# Altium schematic sheet XYPowerSupplyMB-Rev2b.SchDoc(XYPowerSupplyMB-Rev2b)
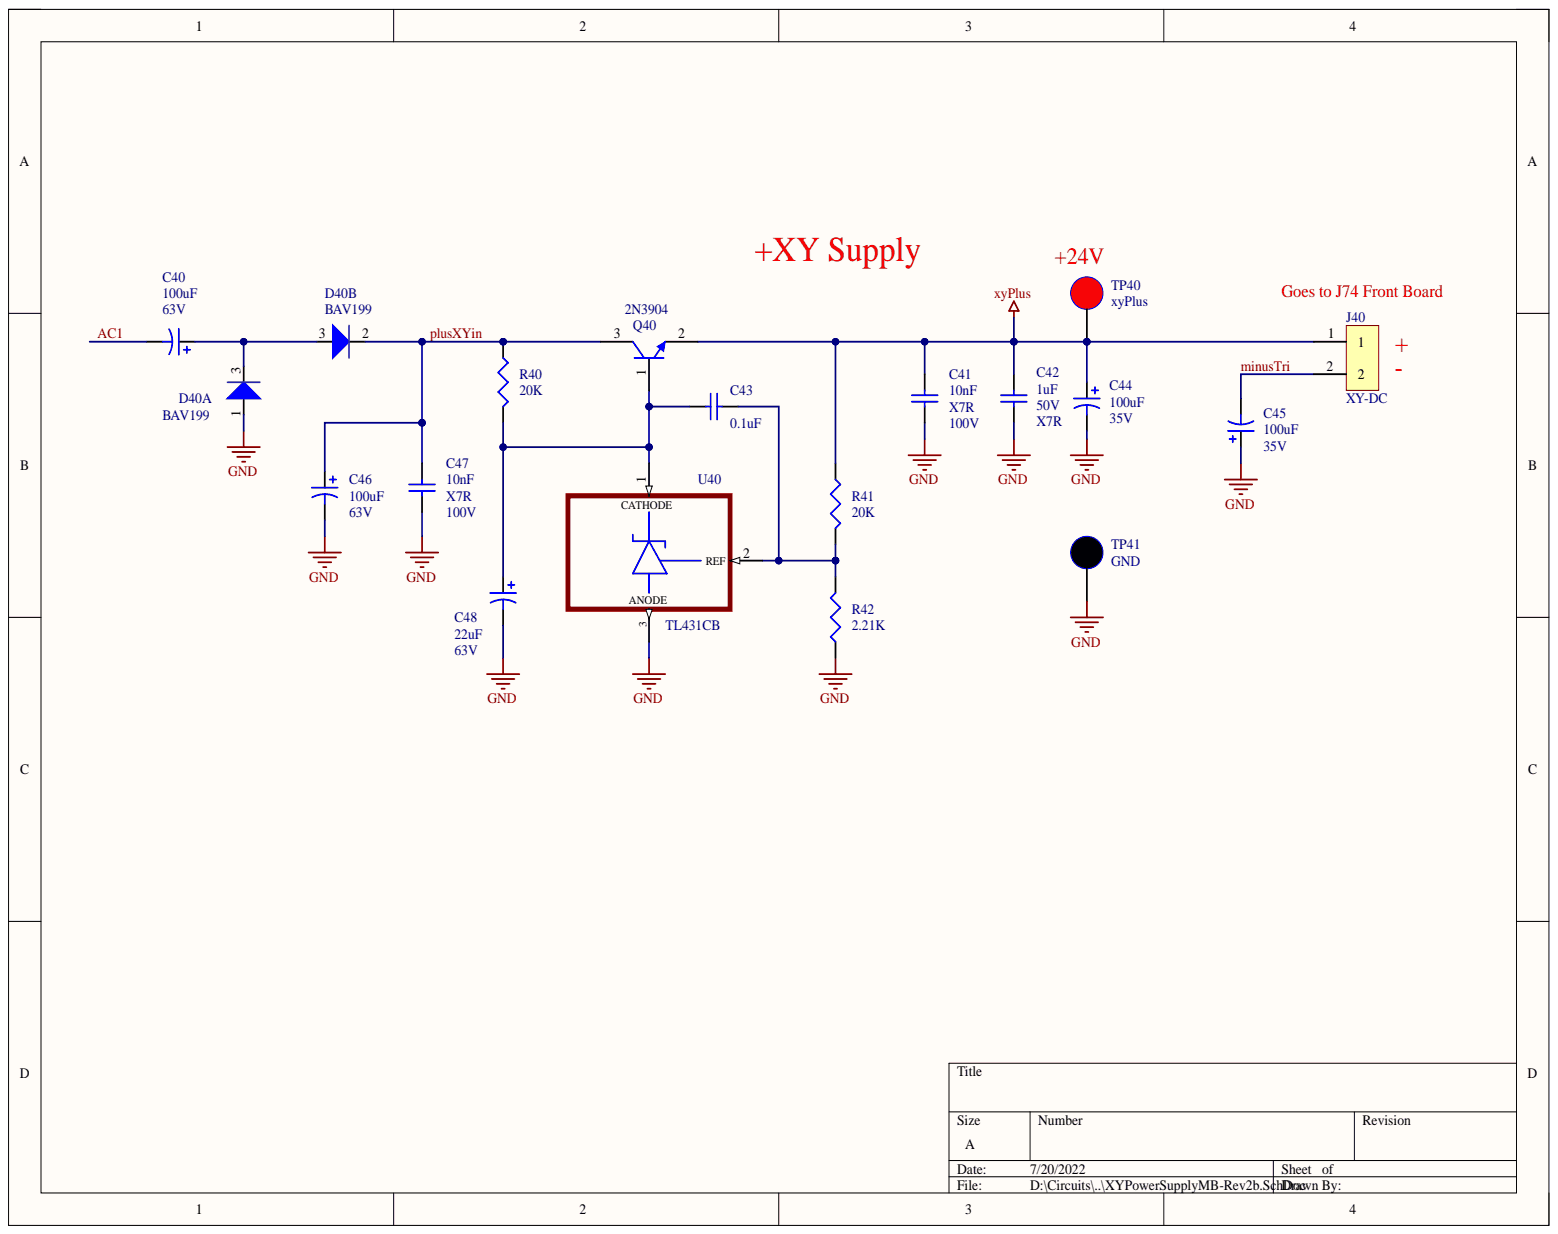
[diagram p0: XYPowerSupplyMB-Rev2b.SchDoc]

## Parts
C40  100uF  Voltage Rating: 63V  [CAPPR3.5-8X12-0.7d]
C41  10nF  Dielectric: X7R  Voltage: 100V  [CAPC2012M]
C42  1uF  Dielectric: X7R  Voltage: 50V  [CAPC2012M]
C43  value 0.1uF  [CAPC2012M]  (hidden comment: 10nF)
C44  100uF  Voltage: 35V  [CAPPR2.5-6.3X10]
C45  100uF  Voltage: 35V  [CAPPR2.5-6.3X10]
C46  100uF  Voltage Rating: 63V  [CAPPR3.5-8X12-0.7d]
C47  10nF  Dielectric: X7R  Voltage: 100V  [CAPC2012M]
C48  value 22uF  Capacitance: 22uF  Voltage: 63V  [CAPPR2-5x11]  (hidden comment: 100uF)
D40  BAV199  [SOT23-3M]  (parts A,B)
J40  XY-DC  [DTA-100-2]
Q40  2N3904  [SOT23-3M]
R40  20K  [RESC2012M]
R41  20K  [RESC2012M]
R42  2.21K  [RESC2012M]
TP40  xyPlus  [TP-.038]
TP41  GND  [TP-.038]
U40  TL431CB  [SOT23-3M]

## Nets
GND: C41.2 C42.2 C44.2 C45.1 C46.2 C47.2 C48.2 D40.1 R42.2 TP41.1 U40.3
xyPlus: C41.1 C42.1 C44.1 J40.1 Q40.2 R41.1 TP40.1
AC1: C40.2
minusTri: C45.2 J40.2
plusXYin: C46.1 C47.1 D40.2 Q40.3 R40.1
NetC40_1: C40.1 D40.3
NetC43_1: C43.1 R41.2 R42.1 U40.2
NetC43_2: C43.2 C48.1 Q40.1 R40.2 U40.1

## Library data of the parts
C40 C46 = Cap Pol1: CAP ALUM 100UF 63V 20%; Manufacturer: KEMET; Manufacturer Part Number: ESL107M063AG8AA; ESR (Equivalent Series Resistance): 170mR; Life (Hours): 10000Hour; Polarity: Polar; Capacitance: 100uF; Case/Package: Radial; Category: Aluminum Electrolytic Capacitors; Component Kind: Standard; ComponentLink1Description: http://www.farnell.com/datasheets/1879581.pdf?src-supplier=Farnell; ComponentLink1URL: http://www.farnell.com/datasheets/1879581.pdf?src-supplier=Farnell; ComponentLink2Description: http://www.produktinfo.conrad.com/datenblaetter/1200000-1299999/001280187-da-01-en-WCAP_ATG8_ALU_ELEC_CAPS_860010674014.pdf?src-supplier=Conrad; ComponentLink2URL: http://www.produktinfo.conrad.com/datenblaetter/1200000-1299999/001280187-da-01-en-WCAP_ATG8_ALU_ELEC_CAPS_860010674014.pdf?src-supplier=Conrad; ComponentLink3Description: http://katalog.we-online.de/pbs/datasheet/860010674014.pdf?src-supplier=Digi-Key; ComponentLink3URL: http://katalog.we-online.de/pbs/datasheet/860010674014.pdf?src-supplier=Digi-Key; ComponentLink4Description: http://www.farnell.com/datasheets/1879581.pdf?src-supplier=Newark; ComponentLink4URL: http://www.farnell.com/datasheets/1879581.pdf?src-supplier=Newark; ComponentLink5Description: http://www.farnell.com/datasheets/1879581.pdf?src-supplier=Element14; ComponentLink5URL: http://www.farnell.com/datasheets/1879581.pdf?src-supplier=Element14; ComponentLink6Description: https://api.kemet.com/component-edge/download/datasheet/ESL107M063AG8AA.pdf?src-supplier=Digi-Key; ComponentLink6URL: https://api.kemet.com/component-edge/download/datasheet/ESL107M063AG8AA.pdf?src-supplier=Digi-Key; Library Name: Miscellaneous Devices.IntLib; Max Operating Temperature: 105°C; Min Operating Temperature: -40°C; Mount: Through Hole; Packaging: Bulk; Pricing 1: 1=0.52000, 10=0.36500, 50=0.27600, 100=0.24030, 500=0.17802, 1000=0.15132, 2500=0.14242, 5000=0.13352 (USD); RoHS: Yes; RoHS Compliant: Yes; Signal Integrity: Cap Pol; Simulation: CAP; Stock 1: 4994; Supplier: Digi-Key; Supplier Part Number: 399-15743-ND; Tolerance: 20%; Value: 100uF
C41 C47 = Cap: CAP CER 10NF 100V X7R 0805; Manufacturer: Samsung; Manufacturer Part Number: CL21B103KCANNNC; Dielectric Material: Ceramic; Dissipation Factor: 2.5%; Tolerance: 10%; Capacitance: 10nF; Case Code (Imperial): 0805; Case Code (Metric): 2012; Case/Package: 0805; Component Kind: Standard; Construction: Flat; Contact Plating: Tin; Depth: 1.25mm; Height: 0.65mm; Length: 2mm; Library Name: Miscellaneous Devices.IntLib; Material: Ceramic; Max Operating Temperature: 125°C; Min Operating Temperature: -55°C; Mount: Surface Mount; Number of Pins: 2; Package Quantity: 1; Packaging: Tape and Reel; Radiation Hardening: No; REACH SVHC: No SVHC; RoHS Compliant: Yes; Series: CL; Signal Integrity: Cap; Simulation: CAP; Supplier: Digi-Key; Supplier Part Number: 1276-1249-6-ND; Termination: SMD/SMT; Thickness: 0.03inch; Value: 10nF; Voltage Rating: 100V; Voltage Rating (DC): 100V; Width: 0.049inch
C42 = Cap: CAP CER 1UF 50V X7R 0805; Manufacturer: Samsung; Manufacturer Part Number: CL21B105KBFNNNE; Dielectric Material: Ceramic; Tolerance: 10%; Capacitance: 1uF; Case Code (Imperial): 0805; Case Code (Metric): 2012; Case/Package: 0805; Component Kind: Standard; Construction: Flat; Depth: 1.25mm; Height: 1.25mm; Length: 2mm; Library Name: Miscellaneous Devices.IntLib; Max Operating Temperature: 125°C; Min Operating Temperature: -55°C; Mount: Surface Mount; Number of Pins: 2; Package Quantity: 1; Packaging: Tape and Reel; Radiation Hardening: No; REACH SVHC: No SVHC; RoHS Compliant: Yes; Series: CL; Signal Integrity: Cap; Simulation: CAP; Supplier: Digi-Key; Supplier Part Number: 1276-1029-6-ND; Termination: SMD/SMT; Thickness: 0.053inch; Value: 1uF; Voltage Rating: 50V; Voltage Rating (DC): 50V; Width: 0.049inch
C43 = Cap: CAP CER 0.1UF 50V X7R 0805; Manufacturer: KEMET; Manufacturer Part Number: C0805C104M5RACTU; Capacitance: 100nF; Dielectric Material: Ceramic; Dissipation Factor: 2.5%; Tolerance: 20%; Case Code (Imperial): 0805; Case Code (Metric): 2012; Case/Package: 0805; Category: Ceramic Capacitors; Component Kind: Standard; ComponentLink1Description: http://www.farnell.com/datasheets/2739857.pdf?src-supplier=Farnell; ComponentLink1URL: http://www.farnell.com/datasheets/2739857.pdf?src-supplier=Farnell; ComponentLink2Description: http://components.arrow.com/part/dataview/0323_00324812?src-supplier=Arrow+Europe; ComponentLink2URL: http://components.arrow.com/part/dataview/0323_00324812?src-supplier=Arrow+Europe; ComponentLink3Description: https://www.verical.com/server-webapp/html/getDatasheet?partID=774625&src-supplier=Verical; ComponentLink3URL: https://www.verical.com/server-webapp/html/getDatasheet?partID=774625&src-supplier=Verical; ComponentLink4Description: http://uk.rs-online.com/webdocs/1515/0900766b81515223.pdf?src-supplier=RSComponents; ComponentLink4URL: http://uk.rs-online.com/webdocs/1515/0900766b81515223.pdf?src-supplier=RSComponents; ComponentLink5Description: http://uk.rs-online.com/webdocs/1528/0900766b81528e2f.pdf?src-supplier=RSComponents; ComponentLink5URL: http://uk.rs-online.com/webdocs/1528/0900766b81528e2f.pdf?src-supplier=RSComponents; ComponentLink6Description: http://uk.rs-online.com/webdocs/13da/0900766b813daa06.pdf?src-supplier=RSComponents; ComponentLink6URL: http://uk.rs-online.com/webdocs/13da/0900766b813daa06.pdf?src-supplier=RSComponents; ComponentLink7Description: http://components.arrow.com/part/dataview/2091108S8109171N7429?src-supplier=Arrow+Electronics; ComponentLink7URL: http://components.arrow.com/part/dataview/2091108S8109171N7429?src-supplier=Arrow+Electronics; ComponentLink8Description: http://www.alliedelec.com/m/d/9bfe7773041ffe9d406e68bc7b377fdd.pdf?src-supplier=Allied+Electronics; ComponentLink8URL: http://www.alliedelec.com/m/d/9bfe7773041ffe9d406e68bc7b377fdd.pdf?src-supplier=Allied+Electronics; ComponentLink9Description: https://api.kemet.com/component-edge/download/datasheet/C0805C104M5RACTU.pdf?src-supplier=Digi-Key; ComponentLink9URL: https://api.kemet.com/component-edge/download/datasheet/C0805C104M5RACTU.pdf?src-supplier=Digi-Key; ComponentLink10Description: http://www.farnell.com/datasheets/2739857.pdf?src-supplier=Element14; ComponentLink10URL: http://www.farnell.com/datasheets/2739857.pdf?src-supplier=Element14; ComponentLink11Description: http://www.farnell.com/datasheets/2739857.pdf?src-supplier=Newark; ComponentLink11URL: http://www.farnell.com/datasheets/2739857.pdf?src-supplier=Newark; ComponentLink12Description: http://capacitoredge.kemet.com/capedge2/DataSheet?pn=C0805C104M5RACTU&src-supplier=Avnet+Europe; ComponentLink12URL: http://capacitoredge.kemet.com/capedge2/DataSheet?pn=C0805C104M5RACTU&src-supplier=Avnet+Europe; ComponentLink13Description: https://www.verical.com/server-webapp/html/getDatasheet?partID=91523&src-supplier=Verical; ComponentLink13URL: https://www.verical.com/server-webapp/html/getDatasheet?partID=91523&src-supplier=Verical; ComponentLink14Description: http://www.mouser.com/ds/2/212/KEM_C1002_X7R_SMD-1102033.pdf?src-supplier=Mouser; ComponentLink14URL: http://www.mouser.com/ds/2/212/KEM_C1002_X7R_SMD-1102033.pdf?src-supplier=Mouser; Construction: Flat; Depth: 1.25mm; Dielectric: X7R; Height: 0.9mm; Length: 2mm; Library Name: Miscellaneous Devices.IntLib; Max Operating Temperature: 125°C; Min Operating Temperature: -55°C; Mount: Surface Mount,PCB; Number of Pins: 2; Package Quantity: 1; Packaging: Tape and Reel; Pricing 1: 4000=0.01341, 8000=0.01224 (USD); Radiation Hardening: No; REACH SVHC: No SVHC; RoHS: Yes; Series: C; Signal Integrity: Cap; Simulation: CAP; Stock 1: 1771581; Supplier: Digi-Key; Supplier Part Number: 399-C0805C104M5RAC7800TR-ND; Termination: SMD/SMT; Thickness: 0.035inch; Voltage: 50V; Voltage Rating: 50V; Voltage Rating (DC): 50V; Weight: 0.000194oz; Width: 1.2mm
C44 C45 = Cap Pol1: CAP ALUM 100UF 20% 35V THRU HOLE; Manufacturer: Rubycon; Manufacturer Part Number: 35YXJ100M6.3X11; Impedance: 220mR; Lead Diameter: 0.5mm; Leakage Current: 3uA; Life (Hours): 7000Hour; Polarity: Polar; Ripple Current: 400mA; Capacitance: 100uF; Case/Package: Radial; Component Kind: Standard; Diameter: 6.3mm; Height: 11mm; Height - Seated (Max): 0.492inch; Lead Pitch: 2.5mm; Library Name: Miscellaneous Devices.IntLib; Max Operating Temperature: 105°C; Min Operating Temperature: -40°C; Mount: Through Hole; Package Quantity: 3000; Packaging: Bulk; Radiation Hardening: No; Signal Integrity: Cap Pol; Simulation: CAP; Supplier: Digi-Key; Supplier Part Number: 1189-2260-ND; Termination: Radial; Tolerance: 20%; Value: 100uF; Voltage Rating: 35V
C48 = Cap Pol1: CAP ALUM 22UF 63V 20%; Manufacturer: Rubycon; Manufacturer Part Number: 63PK22MEFC5X11; Lead Diameter: 0.5mm; Leakage Current: 3uA; Life (Hours): 2000Hour; Ripple Current: 110mA; Case/Package: Radial; Category: Aluminum Electrolytic Capacitors; Component Kind: Standard; ComponentLink1Description: http://www.farnell.com/datasheets/1879581.pdf?src-supplier=Farnell; ComponentLink1URL: http://www.farnell.com/datasheets/1879581.pdf?src-supplier=Farnell; ComponentLink2Description: http://www.produktinfo.conrad.com/datenblaetter/1200000-1299999/001280187-da-01-en-WCAP_ATG8_ALU_ELEC_CAPS_860010674014.pdf?src-supplier=Conrad; ComponentLink2URL: http://www.produktinfo.conrad.com/datenblaetter/1200000-1299999/001280187-da-01-en-WCAP_ATG8_ALU_ELEC_CAPS_860010674014.pdf?src-supplier=Conrad; ComponentLink3Description: http://katalog.we-online.de/pbs/datasheet/860010674014.pdf?src-supplier=Digi-Key; ComponentLink3URL: http://katalog.we-online.de/pbs/datasheet/860010674014.pdf?src-supplier=Digi-Key; ComponentLink4Description: http://www.farnell.com/datasheets/1879581.pdf?src-supplier=Newark; ComponentLink4URL: http://www.farnell.com/datasheets/1879581.pdf?src-supplier=Newark; ComponentLink5Description: http://www.farnell.com/datasheets/1879581.pdf?src-supplier=Element14; ComponentLink5URL: http://www.farnell.com/datasheets/1879581.pdf?src-supplier=Element14; ComponentLink6Description: https://datasheet.ciiva.com/46385/1657883-46385279.pdf?src-supplier=Element14; ComponentLink6URL: https://datasheet.ciiva.com/46385/1657883-46385279.pdf?src-supplier=Element14; ComponentLink7Description: https://datasheet.ciiva.com/25613/0900766b810f8819-25613314.pdf?src-supplier=RSComponents; ComponentLink7URL: https://datasheet.ciiva.com/25613/0900766b810f8819-25613314.pdf?src-supplier=RSComponents; Construction: RADIAL; Diameter: 5mm; Height: 11mm; Lead Pitch: 2mm; Library Name: Miscellaneous Devices.IntLib; Max Operating Temperature: 85°C; Min Operating Temperature: -40°C; Mount: Through Hole; Pricing 1: 1=0.30000, 10=0.20700, 50=0.13800, 100=0.11730, 500=0.08280, 1000=0.07245, 2500=0.06900, 5000=0.06382 (USD); Radiation Hardening: No; RoHS: Yes; RoHS Compliant: Yes; Signal Integrity: Cap Pol; Simulation: CAP; Stock 1: 404; Supplier: Digi-Key; Supplier Part Number: 63PK22MEFC5X11-ND; Termination: Radial; Tolerance: 20%
D40 = Dual-Si-Series-Separate: Rectifier Diode Switching 85V 0.16A 3000ns Automotive 3-Pin SOT-23 T/R; Manufacturer: Nexperia; Manufacturer Part Number: BAV199,215; Category: Rectifier Diodes; Component Kind: Standard; ComponentLink1Description: http://www.farnell.com/datasheets/2242062.pdf?src-supplier=Farnell; ComponentLink1URL: http://www.farnell.com/datasheets/2242062.pdf?src-supplier=Farnell; Library Name: MY_Diodes.SchLib; Pricing 1: 1=0.23000, 10=0.18900, 100=0.10030, 500=0.06600, 1000=0.04488 (USD); RoHS: Yes; RoHS Compliant: Yes; Stock 1: 68397; Supplier: Digi-Key; Supplier Part Number: 1727-2909-1-ND; Value: BAV199
J40 = 2-Pin: 2.54mm 2pin; Manufacturer: TE Connectivity; Manufacturer Part Number: 640456-2; Approvals: CSA; Backwall/Post Interruptions: No (Without); Circuit Application: Signal; Connector System: Wire-to-Board; Connector Type: Connector,Pin,Plug,Header; Contact Current Rating: 5A; Contact Post Length: 3.56mm; Contact Resistance: 6mR; Contact Style: Straight; Gender: Male; Mating Connector Lock: Yes (With); Max Voltage Rating (AC): 250V; Number of Contacts: 2; PCB Mount Retention: Without; PCB Mounting Orientation: Vertical; PCB Thickness: 0.063inch; Plating Thickness: 3.81um; Tail Length: 0.14inch; Value: 640456-2; Category: Headers and Wire Housings; Color: Natural,White,Beige; Component Kind: Standard; ComponentLink1Description: https://datasheet.ciiva.com/709/eng-cd-640456-w1-709680.pdf?src-supplier=Digi-Key; ComponentLink1URL: https://datasheet.ciiva.com/709/eng-cd-640456-w1-709680.pdf?src-supplier=Digi-Key; ComponentLink2Description: https://datasheet.ciiva.com/3118/eng-ds-82056-mta-0608-3118497.pdf?src-supplier=Avnet; ComponentLink2URL: https://datasheet.ciiva.com/3118/eng-ds-82056-mta-0608-3118497.pdf?src-supplier=Avnet; ComponentLink3Description: https://datasheet.ciiva.com/16401/eng-ds-82056-mta-0608-16401289.pdf?src-supplier=Future+Electronics; ComponentLink3URL: https://datasheet.ciiva.com/16401/eng-ds-82056-mta-0608-16401289.pdf?src-supplier=Future+Electronics; ComponentLink4Description: https://datasheet.ciiva.com/25617/0900766b80027008-25617244.pdf?src-supplier=RSComponents; ComponentLink4URL: https://datasheet.ciiva.com/25617/0900766b80027008-25617244.pdf?src-supplier=RSComponents; ComponentLink5Description: https://datasheet.ciiva.com/14156/04384301-14156820.pdf?src-supplier=Distrelec; ComponentLink5URL: https://datasheet.ciiva.com/14156/04384301-14156820.pdf?src-supplier=Distrelec; ComponentLink6Description: https://datasheet.ciiva.com/11746/0900766b80eb7fdd-11746365.pdf?src-supplier=RSComponents; ComponentLink6URL: https://datasheet.ciiva.com/11746/0900766b80eb7fdd-11746365.pdf?src-supplier=RSComponents; ComponentLink7Description: https://datasheet.ciiva.com/7128/1866390-7128742.pdf?src-supplier=Newark; ComponentLink7URL: https://datasheet.ciiva.com/7128/1866390-7128742.pdf?src-supplier=Newark; ComponentLink8Description: https://datasheet.ciiva.com/16505/eng-ds-82056-mta-0608-16505419.pdf?src-supplier=Avnet+Asia; ComponentLink8URL: https://datasheet.ciiva.com/16505/eng-ds-82056-mta-0608-16505419.pdf?src-supplier=Avnet+Asia; ComponentLink9Description: https://datasheet.ciiva.com/18620/1713017-18620870.pdf?src-supplier=Digi-Key; ComponentLink9URL: https://datasheet.ciiva.com/18620/1713017-18620870.pdf?src-supplier=Digi-Key; ComponentLink10Description: https://datasheet.ciiva.com/16047/1989748-16047438.pdf?src-supplier=Onlinecomponents.com; ComponentLink10URL: https://datasheet.ciiva.com/16047/1989748-16047438.pdf?src-supplier=Onlinecomponents.com; ComponentLink11Description: https://datasheet.ciiva.com/11758/0900766b811d404e-11758587.pdf?src-supplier=RSComponents; ComponentLink11URL: https://datasheet.ciiva.com/11758/0900766b811d404e-11758587.pdf?src-supplier=RSComponents; ComponentLink12Description: https://datasheet.ciiva.com/6746/combiconspring-cagepcbterm-blocks-6746175.pdf?src-supplier=Digi-Key; ComponentLink12URL: https://datasheet.ciiva.com/6746/combiconspring-cagepcbterm-blocks-6746175.pdf?src-supplier=Digi-Key; ComponentLink13Description: https://datasheet.ciiva.com/14607/70055059-14607702.pdf?src-supplier=Allied+Electronics; ComponentLink13URL: https://datasheet.ciiva.com/14607/70055059-14607702.pdf?src-supplier=Allied+Electronics; ComponentLink14Description: https://datasheet.ciiva.com/16051/1988956-16051359.pdf?src-supplier=Onlinecomponents.com; ComponentLink14URL: https://datasheet.ciiva.com/16051/1988956-16051359.pdf?src-supplier=Onlinecomponents.com; ComponentLink15Description: https://datasheet.ciiva.com/26340/567541-da-01-de-printklemme-1988956-250-st-26340451.pdf?src-supplier=Conrad; ComponentLink15URL: https://datasheet.ciiva.com/26340/567541-da-01-de-printklemme-1988956-250-st-26340451.pdf?src-supplier=Conrad; ComponentLink16Description: https://datasheet.ciiva.com/824/combiconcompactseries-824224.pdf?src-supplier=Digi-Key; ComponentLink16URL: https://datasheet.ciiva.com/824/combiconcompactseries-824224.pdf?src-supplier=Digi-Key; ComponentLink17Description: https://datasheet.ciiva.com/14609/70055171-14609100.pdf?src-supplier=Allied+Electronics; ComponentLink17URL: https://datasheet.ciiva.com/14609/70055171-14609100.pdf?src-supplier=Allied+Electronics; Contact Gender: Male; Contact Material: Copper Alloy; Contact Mating Length: 7.49mm; Contact Plating: Tin; Contact Retention: No (Without); Contact Shape: Square; Contact Type: Male Pin; Current Rating: 5A; Depth: 5.72mm; ELV: Compliant; Fastening Type: Friction; Flammability Rating: UL94 V-0; Height: 7.87mm; Housing Color: Natural; Housing Material: Polyester; Lead Free: Lead Free; Lead Pitch: 2.54mm; Length: 7.62mm; Library Name: MY_CONNECTORS.SchLib; Mating Alignment: No (Without); Mating Retention: No (Without); Max Operating Temperature: 221°C; Min Operating Temperature: -67°C; Mount: Through Hole; Mounting Ears: No (Without); Number of Poles: 2; Number of Positions: 2; Number of Rows: 1; Orientation: Straight; Package Quantity: 5000; Packaging: Bulk; Panel Mount Retention: No (Without); PCB Mount Alignment: No (Without); Pitch: 2.54mm; Pricing 1: 1=0.13000, 10=0.10400, 100=0.07700, 500=0.06374, 1000=0.05578, 5000=0.04781, 10000=0.04648 (USD); Radiation Hardening: No; REACH SVHC: No SVHC; RoHS: Yes; RoHS Compliant: Yes; Sealable: No (Without); Series: MTA-100; Shrouded: No (Without); Stock 1: 18986; Strain Relief: No (Without); Supplier: Digi-Key; Supplier Part Number: A1921-ND; Termination: Solder; Voltage Rating: 250V; Voltage Rating (AC): 250V; Working Voltage: 250V
Q40 = 2N3904: TRANS NPN 40V 0.2A SOT23; Manufacturer: ON Semiconductor; Manufacturer Part Number: MMBT3904LT1G; Current - Collector (Ic) (Max): 200mA; DC Current Gain (hFE) (Min) @ Ic, Vce: 100 @ 10mA, 1V; Frequency - Transition: 300MHz; Power - Max: 300mW; Vce Saturation (Max) @ Ib, Ic: 300mV @ 5mA, 50mA; Category: Discrete Semiconductor Products; Code_JEDEC: TO-92A; Component Kind: Standard; ComponentLink1Description: Manufacturer Link; ComponentLink1URL: http://www.fairchildsemi.com/; ComponentLink2Description: Datasheet; ComponentLink2URL: http://www.fairchildsemi.com/ds/2N%2F2N3904.pdf; LatestRevisionDate: 15-Jan-2003; LatestRevisionNote: PCB Footprint 'BCY-W3/D4.7' replaced; Library Name: My_Miscellaneous Devices.SchLib; Mounting Type: Surface Mount; Operating Temperature: -55°C ~ 150°C (TJ); Package / Case: TO-236-3, SC-59, SOT-23-3; PackageVersion: Sep-1998; Packaging: Cut Tape (CT); Part Status: Active; RoHS: RoHS Compliant; Signal Integrity: 2N3904; Simulation: 2N3904; Supplier: Digi-Key; Supplier Device Package: SOT-23-3 (TO-236); Supplier Part Number: MMBT3904LT1GOSCT-ND; Transistor Type: NPN; Value: 2N3904; Voltage - Collector Emitter Breakdown (Max): 40V
R40 R41 = Res3: RES 20K OHM 1% 1/4W 0805; Manufacturer: KOA Speer; Manufacturer Part Number: RK73H2ATTD2002F; Power Rating: 125mW; Resistance: 20kR; Temperature Coefficient: 100ppm/°C; Voltage Rating: 150V; Case Code (Imperial): 0805; Case Code (Metric): 2012; Case/Package: 0805; Component Kind: Standard; Construction: Rectangular; Depth: 1.25mm; Height: 0.5mm; Lead Free: Lead Free; Length: 2mm; Library Name: Miscellaneous Devices.IntLib; Max Operating Temperature: 155°C; Military Standard: Not; Min Operating Temperature: -55°C; Package Quantity: 5000; Packaging: Tape and Reel; REACH SVHC: Unknown; Resistance Tolerance: 1%; Resistor Type: Thick Film; RoHS Compliant: Yes; Series: RK73H; Signal Integrity: Res; Simulation: RESISTOR; Supplier: Digi-Key; Supplier Part Number: 2019-RK73H2ATTD2002FDKR-ND; Termination: SMD/SMT; Tolerance: 1%; Value: 20K; Voltage Rating (DC): 150V; Width: 1.25mm
R42 = Res3: RES 2.21K OHM 1% 1/4W 0805; Manufacturer: KOA Speer; Manufacturer Part Number: RK73H2ATTD2211F; Manufacturer Series: RK73H; Additional Feature: PRECISION; Power Rating: 125mW; Resistance: 2.21kR; Temperature Coefficient: 100ppm/°C; Voltage Rating: 150V; Case Code (Imperial): 0805; Case Code (Metric): 2012; Case/Package: 0805; Component Kind: Standard; Construction: Rectangular; Depth: 1.25mm; Height: 0.5mm; Lead Free: Lead Free; Length: 2mm; Library Name: Miscellaneous Devices.IntLib; Max Operating Temperature: 155°C; Military Standard: Not; Min Operating Temperature: -55°C; Package Quantity: 5000; Package Shape: Rectangular; Packaging: Tape and Reel; Resistor Type: Thick Film; RoHS Compliant: Yes; Series: RK73H; Signal Integrity: Res; Simulation: RESISTOR; Size Code: 0805; Supplier: Digi-Key; Supplier Part Number: 2019-RK73H2ATTD2211FDKR-ND; Technology: METAL GLAZE/THICK FILM; Terminal Finish: Tin; Termination: SMD/SMT; Tolerance: 1%; Value: 2.21K; Voltage Rating (DC): 150V; Width: 1.25mm; Working Voltage: 150V
TP40 = TP-Round-Red: TP Thru-Hole; Manufacturer: UW; Manufacturer Part Number: Gold; Component Kind: Standard; Library Name: MY_TP.SchLib; Supplier: UW; Supplier Part Number: Gold; Value: TP Thru-Hole
TP41 = TP-Round-Black: TP Thru-Hole; Manufacturer: UW; Manufacturer Part Number: Gold; Component Kind: Standard; Library Name: MY_TP.SchLib; Supplier: UW; Supplier Part Number: Gold; Value: TP Thru-Hole
U40 = TL431CLT: 2%, 1%, or 0.5% accuracy, adjustable precision Zener shunt regulator 3-SOT-23 0 to 70; Manufacturer: TI National Semiconductor; Manufacturer Part Number: LM431BCM3/NOPB; Cathode Current: 1mA; Current Rating: 15A; Max Input Voltage: 37V; Max Output Current: 100mA; Min Input Voltage: 3V; Min Output Voltage: 2.495V; Number of Channels: 1; Reference Type: Shunt,Adjustable; Reference Voltage: 36V; Temperature Coefficient: 50ppm/°C; Value: TL431; Case/Package: SOT-23; Category: Voltage References; Component Kind: Standard; ComponentLink1Description: http://components.arrow.com/part/dataview/47118380S426464N2867?src-supplier=Arrow+Electronics; ComponentLink1URL: http://components.arrow.com/part/dataview/47118380S426464N2867?src-supplier=Arrow+Electronics; ComponentLink2Description: http://www.mouser.com/ds/2/115/ZTL431_432-91743.pdf?src-supplier=Mouser; ComponentLink2URL: http://www.mouser.com/ds/2/115/ZTL431_432-91743.pdf?src-supplier=Mouser; ComponentLink3Description: https://media.digikey.com/pdf/Data%20Sheets/Diodes%20PDFs/ZTL431,432.pdf?src-supplier=Digi-Key; ComponentLink3URL: https://media.digikey.com/pdf/Data%20Sheets/Diodes%20PDFs/ZTL431,432.pdf?src-supplier=Digi-Key; ComponentLink4Description: http://uk.rs-online.com/webdocs/104c/0900766b8104c4a4.pdf?src-supplier=RSComponents; ComponentLink4URL: http://uk.rs-online.com/webdocs/104c/0900766b8104c4a4.pdf?src-supplier=RSComponents; ComponentLink5Description: http://www.diodes.com///datasheets/ZTL431_432.pdf?src-supplier=Avnet; ComponentLink5URL: http://www.diodes.com///datasheets/ZTL431_432.pdf?src-supplier=Avnet; ComponentLink6Description: http://www.diodes.com/datasheets/ZTL431_432.pdf?src-supplier=Future+Electronics; ComponentLink6URL: http://www.diodes.com/datasheets/ZTL431_432.pdf?src-supplier=Future+Electronics; ComponentLink7Description: https://datasheet.ciiva.com/25626/0900766b810fa55b-25626292.pdf?src-supplier=RSComponents; ComponentLink7URL: https://datasheet.ciiva.com/25626/0900766b810fa55b-25626292.pdf?src-supplier=RSComponents; ComponentLink8Description: https://datasheet.ciiva.com/22698/1882752-22698613.pdf?src-supplier=Element14; ComponentLink8URL: https://datasheet.ciiva.com/22698/1882752-22698613.pdf?src-supplier=Element14; ComponentLink9Description: https://datasheet.ciiva.com/48473/lm431-48473592.pdf?src-supplier=Avnet; ComponentLink9URL: https://datasheet.ciiva.com/48473/lm431-48473592.pdf?src-supplier=Avnet; ComponentLink10Description: https://datasheet.ciiva.com/2007/lm431-2007595.pdf?src-supplier=Digi-Key; ComponentLink10URL: https://datasheet.ciiva.com/2007/lm431-2007595.pdf?src-supplier=Digi-Key; ComponentLink11Description: https://datasheet.ciiva.com/2007/lm431-2007596.pdf?src-supplier=Digi-Key; ComponentLink11URL: https://datasheet.ciiva.com/2007/lm431-2007596.pdf?src-supplier=Digi-Key; ComponentLink12Description: https://datasheet.ciiva.com/2007/lm431-2007594.pdf?src-supplier=Digi-Key; ComponentLink12URL: https://datasheet.ciiva.com/2007/lm431-2007594.pdf?src-supplier=Digi-Key; Height: 0.93mm; Lead Free: Lead Free; Length: 2.92mm; Library Name: TL431CDBVR.SchLib; Max Operating Temperature: 85°C; Max Output Voltage: 37V; Min Operating Temperature: -40°C; Mount: Surface Mount; Number of Pins: 3; Output Current: 100mA; Output Type: Adjustable; Output Voltage: 37V; Package Quantity: 1000; Packaging: Tape and Reel; Pricing 1: 1=0.90000, 10=0.80100, 25=0.76000, 100=0.62410, 250=0.58344, 500=0.51558 (USD); Radiation Hardening: No; REACH SVHC: No SVHC; RoHS: Yes; RoHS Compliant: Yes; Series: -; Stock 1: 20308; Supplier: Digi-Key; Supplier Part Number: LM431BCM3/NOPBCT-ND; Tolerance: 1%; Width: 1.3mm
